# Altium schematic sheet Sheet5.SchDoc
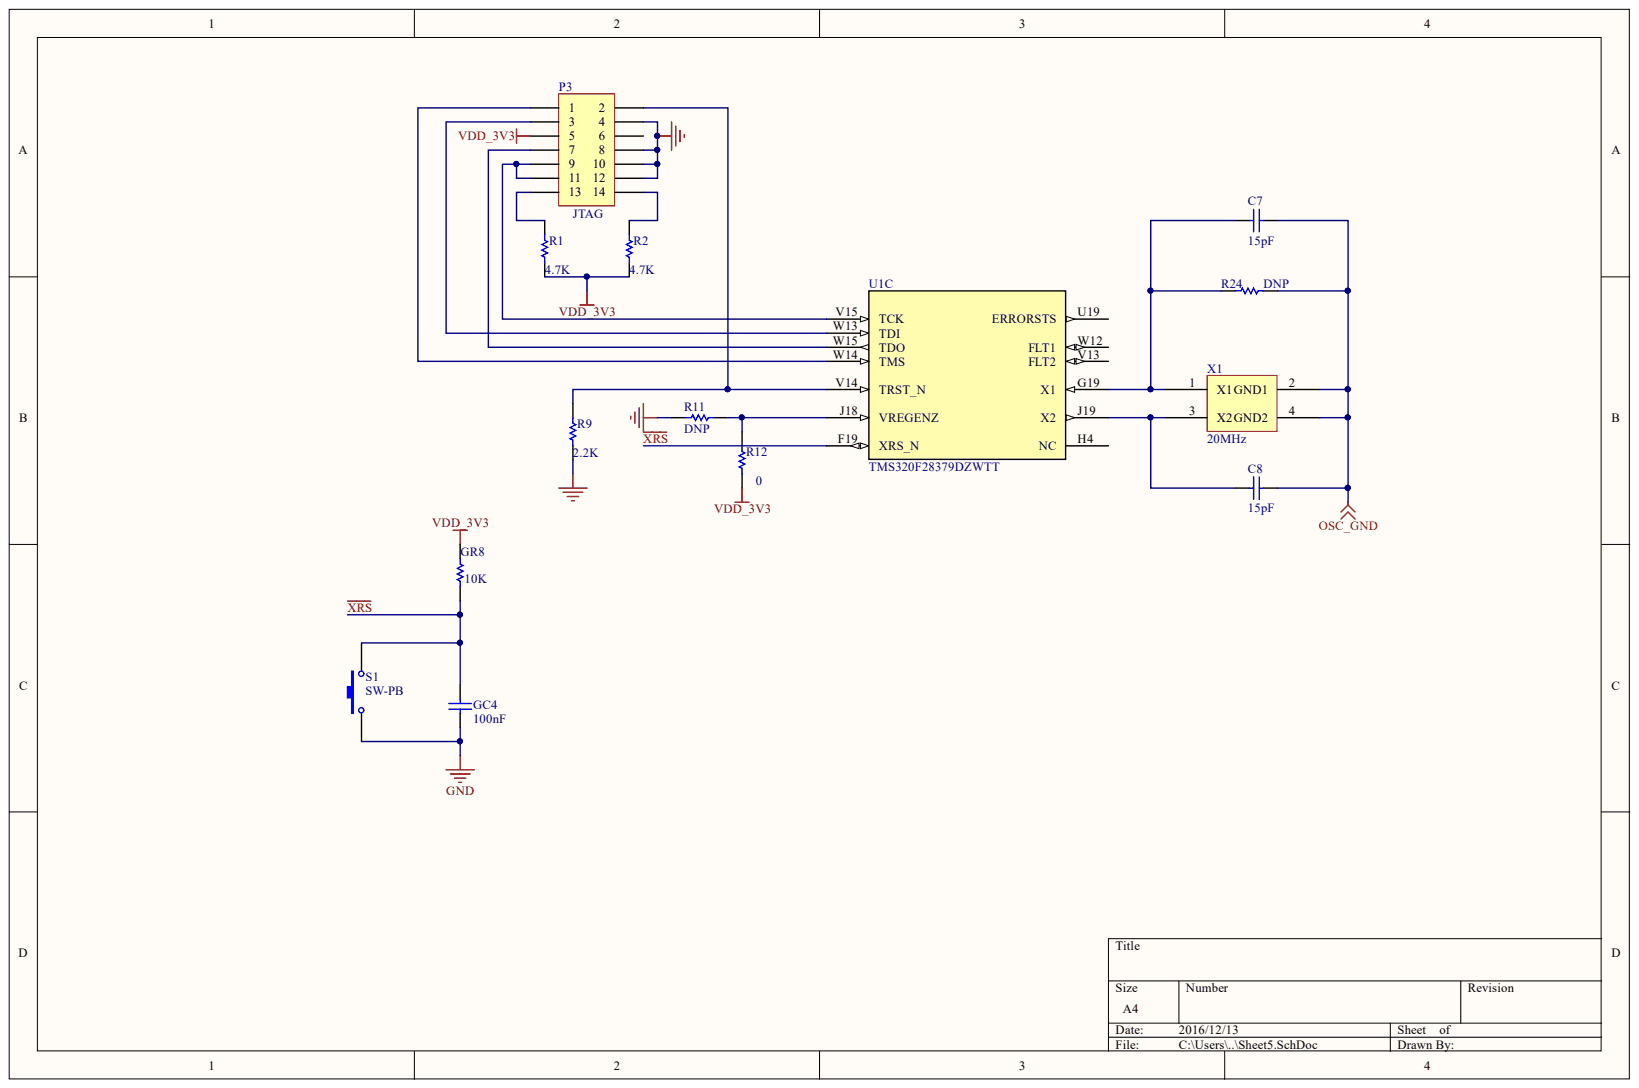
[diagram p0: Sheet5.SchDoc]

## Parts
C7  value 15pF  [0805_TW]  (hidden comment: C_0805)
C8  value 15pF  [0805_TW]  (hidden comment: C_0805)
GC4  value 100nF  [0805_TW]  (hidden comment: C_0805)
GR8  value 10K  [0402_TW]  (hidden comment: R_0402)
P3  JTAG  [HDR2X7]
R1  value 4.7K  [0402_TW]  (hidden comment: R_0402)
R2  value 4.7K  [0402_TW]  (hidden comment: R_0402)
R9  value 2.2K  [0402_TW]  (hidden comment: R_0402)
R11  value DNP  [0402_TW]  (hidden comment: R_0402)
R12  value 0  [0402_TW]  (hidden comment: R_0402)
R24  value DNP  [0402_TW]  (hidden comment: R_0402)
S1  SW-PB  [SW_PB2_A1]
U1  TMS320F28379DZWTT  [ZWT0337A]  (part C)
X1  20MHz

## Nets
GND: GC4.2 P3.4 P3.8 P3.10 P3.12 R9.1 R11.2 S1.1
OSC_GND: C7.1 C8.1 R24.2 X1.2(GND1) X1.4(GND2)
VDD_3V3: GR8.2 P3.5 R1.1 R2.1 R12.1
~{XRS}: GC4.1 GR8.1 S1.2 U1.F19(XRS_N)
NetC7_2: C7.2 R24.1 U1.G19(X1) X1.1
NetC8_2: C8.2 U1.J19(X2) X1.3(X2)
NetP3_1: P3.1 U1.W14(TMS)
NetP3_2: P3.2 R9.2 U1.V14(TRST_N)
NetP3_3: P3.3 U1.W13(TDI)
NetP3_7: P3.7 U1.W15(TDO)
NetP3_9: P3.9 P3.11 U1.V15(TCK)
NetP3_13: P3.13 R1.2
NetP3_14: P3.14 R2.2
NetR11_1: R11.1 R12.2 U1.J18(VREGENZ)

Not connected: P3 6; U1 H4(NC) U19(ERRORSTS) V13(FLT2) W12(FLT1)

## Library data of the parts
P3 = Header 7X2: Header, 7-Pin, Dual row; Component Kind: Standard; LatestRevisionDate: 17-Jul-2002; LatestRevisionNote: Re-released for DXP Platform.; Library Name: Miscellaneous Connectors.IntLib; Signal Integrity: Connector; Publisher: Altium Limited
S1 = SW-PB: 微动开关; Component Kind: Standard; LatestRevisionDate: 17-Jul-2002; LatestRevisionNote: Re-released for DXP Platform.; PackageReference: SPST-2; Published: 24-Mar-1999; Library Name: fszhang.SchLib; Publisher: Altium Limited
U1 = TMS320F28379DZWTT: Imported; Manufacturer: Texas Instruments; Component Kind: Standard; Library Name: TMS320F28379DZWTT.SchLib; PartNumber: TMS320F28379DZWTT; RefDes: RefDes; Type: DEV; Value: Value
X1 = OSC: Component Kind: Standard; Library Name: OSC.SchLib
C7 C8 GC4 = C_0805: Component Kind: Standard; Library Name: TW_Lib.SchLib
GR8 R1 R2 R9 R11 R12 R24 = R_0402: Component Kind: Standard; Library Name: TW_Lib.SchLib
